# Altium schematic sheet RFShield.SchDoc(RFShield)
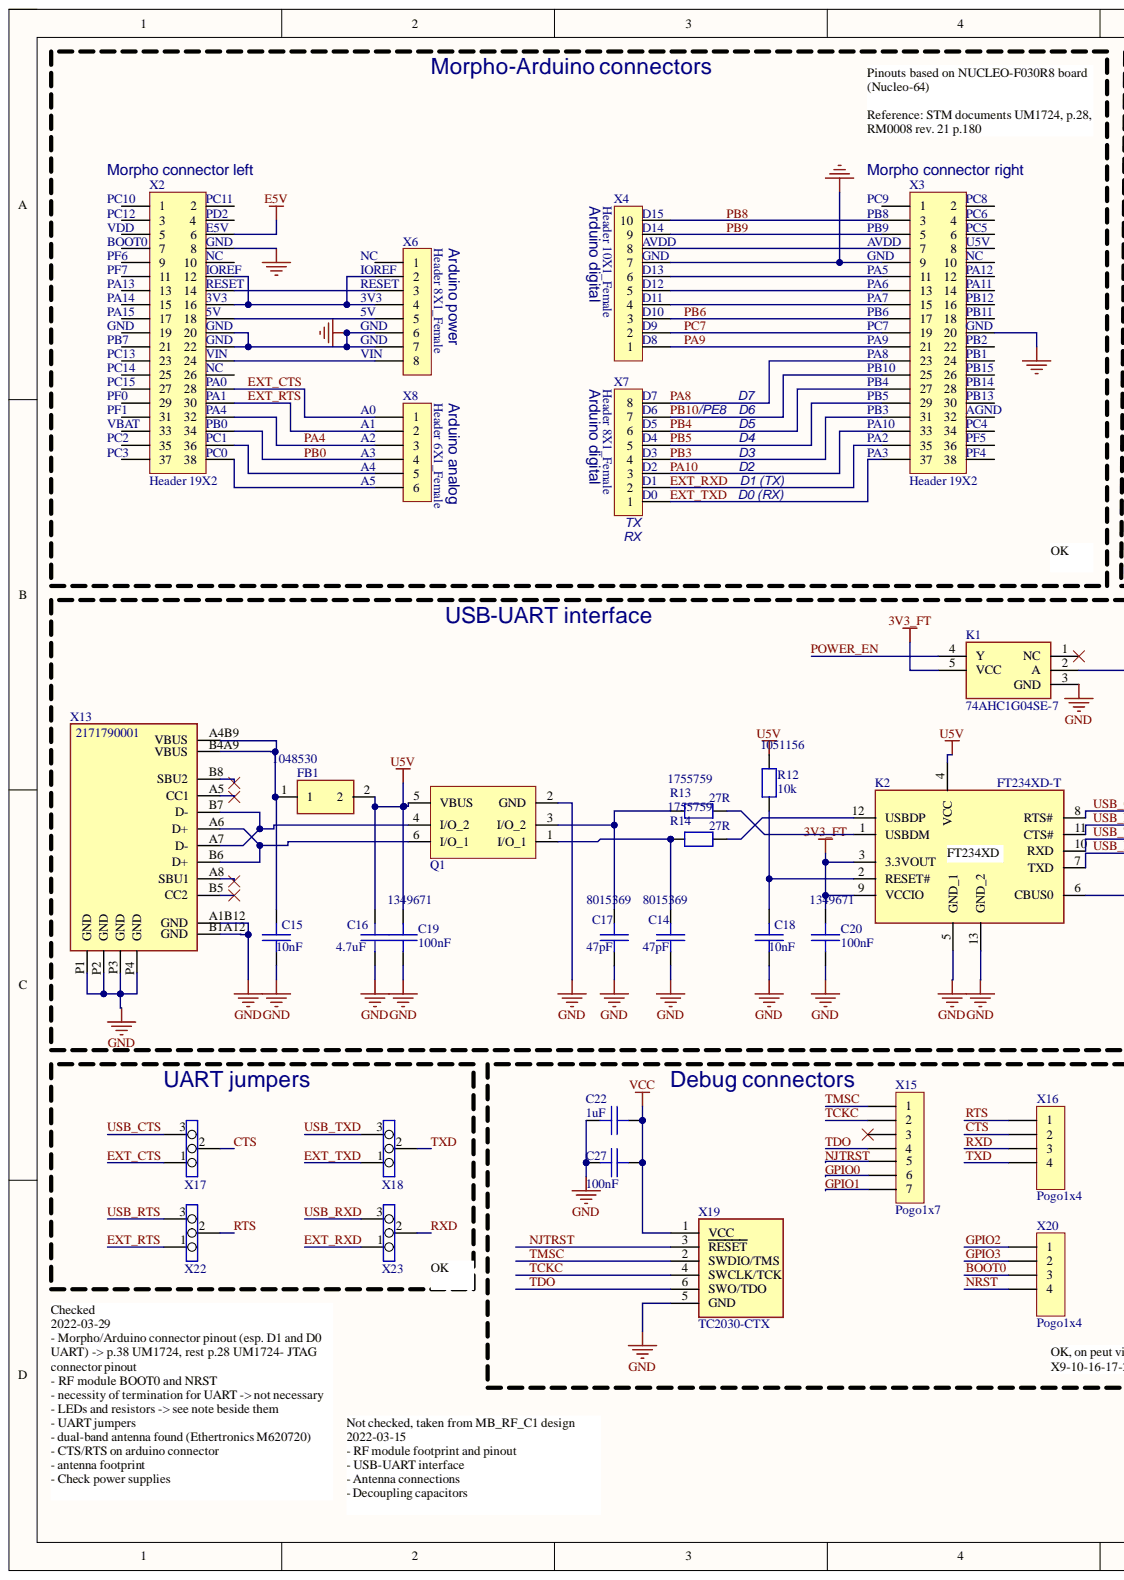
[diagram p0: RFShield.SchDoc, left]
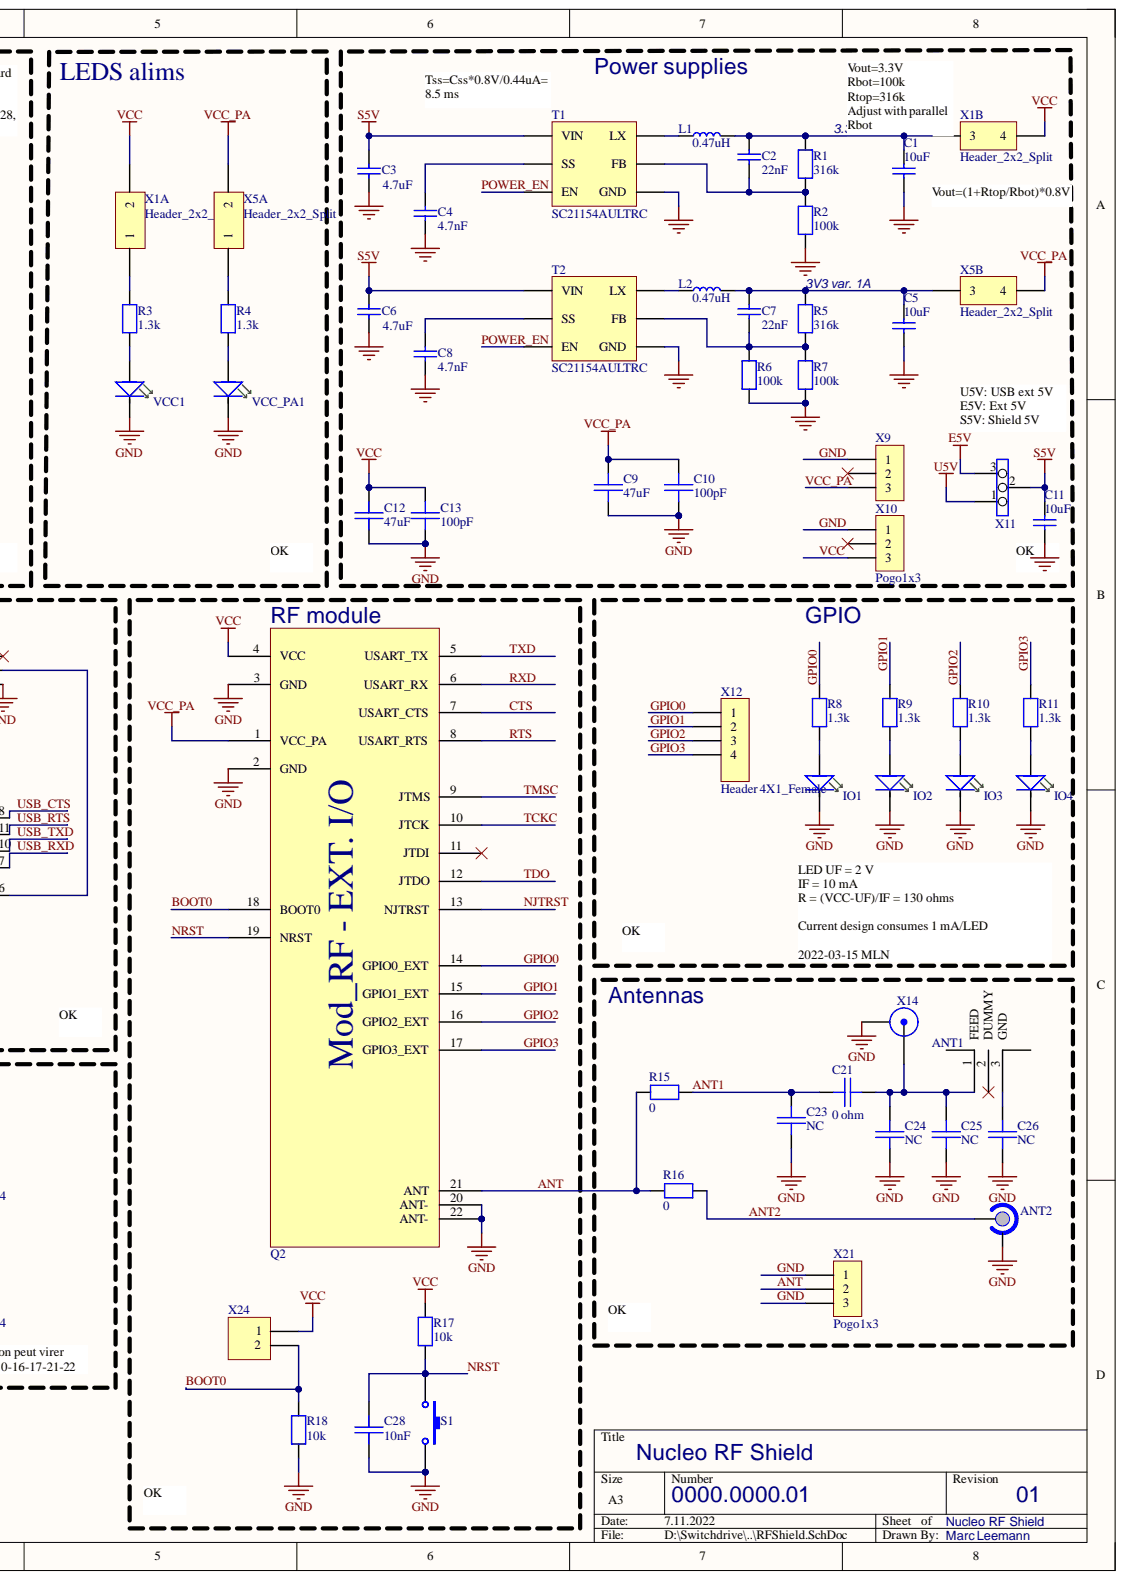
[diagram p1: RFShield.SchDoc, right]

## Parts
ANT1  ANT_M620720  [M620720]  (value not drawn)
ANT2  SMA_ThroughHole_RightAngle  [Amphenol-132225]  (value not drawn)
C1  10uF  [J1-0603]
C2  22nF  [J1-0603]
C3  4.7uF  [J1-0603]
C4  4.7nF  [J1-0603]
C5  10uF  [J1-0603]
C6  4.7uF  [J1-0603]
C7  22nF  [J1-0603]
C8  4.7nF  [J1-0603]
C9  value 47uF  [J1-0603]  (hidden comment: C-47uF-0805)
C10  value 100pF  [J1-0603]  (hidden comment: C-100pF-0603)
C11  10uF  [J1-0603]
C12  value 47uF  [J1-0603]  (hidden comment: C-47uF-0805)
C13  value 100pF  [J1-0603]  (hidden comment: C-100pF-0603)
C14  value 47pF  Supplier Part Number 1: 8015369  [SM/C_0603]  (hidden comment: C-47pF-0402)
C15  value 10nF  [SM/C_0603]  (hidden comment: C-10nF-0603)
C16  value 4.7uF  [SM/C_0805]  (hidden comment: C-4.7uF-0805)
C17  value 47pF  Supplier Part Number 1: 8015369  [SM/C_0603]  (hidden comment: C-47pF-0402)
C18  value 10nF  [SM/C_0603]  (hidden comment: C-10nF-0603)
C19  value 100nF  Supplier Part Number 1: 1349671  [SM/C_0603]  (hidden comment: C-100nF-0402)
C20  value 100nF  Supplier Part Number 1: 1349671  [SM/C_0603]  (hidden comment: C-100nF-0402)
C21  value 0 ohm  [SM/C_0402]  (hidden comment: C-10pF-0402)
C22  value 1uF  [J1-0603]  (hidden comment: C-1uF-0603)
C23  value NC  [SM/C_0402]  (hidden comment: C-10pF-0402)
C24  value NC  [SM/C_0402]  (hidden comment: C-10pF-0402)
C25  value NC  [SM/C_0402]  (hidden comment: C-10pF-0402)
C26  value NC  [SM/C_0402]  (hidden comment: C-10pF-0402)
C27  value 100nF  [J1-0603]  (hidden comment: C-100nF-0603)
C28  value 10nF  [SM/C_0603]  (hidden comment: C-10nF-0603)
FB1  Supplier Part Number 1: 1048530  [SM/C_0603]  (hidden comment: BLM15AX601SN1D)
IO1  LED-GREEN-0603  [SM/D_0603]  (value not drawn)
IO2  LED-GREEN-0603  [SM/D_0603]  (value not drawn)
IO3  LED-GREEN-0603  [SM/D_0603]  (value not drawn)
IO4  LED-GREEN-0603  [SM/D_0603]  (value not drawn)
K1  74AHC1G04SE-7  [SOT65P210X110-5N]
K2  FT234XD-T  [SON45P300X300X80-13N-D]
L1  0.47uH  [6-0805_L]
L2  0.47uH  [6-0805_L]
Q1  USBLC6-2SC6  [SOT95P280X145-6N]  (value not drawn)
Q2  Module_RF_C1  [Module_RF_C1]  (value not drawn)
R1  316k  [J1-0603]
R2  100k  [J1-0603]
R3  value 1.3k  [SM/R_0603]  (hidden comment: R-1.3k-0603)
R4  value 1.3k  [SM/R_0603]  (hidden comment: R-1.3k-0603)
R5  value 316k  [J1-0603]  (hidden comment: 68k)
R6  100k  [J1-0603]
R7  value 100k  [J1-0603]  (hidden comment: 12k)
R8  value 1.3k  [SM/R_0603]  (hidden comment: R-1.3k-0603)
R9  value 1.3k  [SM/R_0603]  (hidden comment: R-1.3k-0603)
R10  value 1.3k  [SM/R_0603]  (hidden comment: R-1.3k-0603)
R11  value 1.3k  [SM/R_0603]  (hidden comment: R-1.3k-0603)
R12  value 10k  Supplier Part Number 1: 1051156  [SM/R_0603]  (hidden comment: R-10k-0402)
R13  value 27R  Supplier Part Number 1: 1755759  [SM/R_0603]  (hidden comment: R-27R-0402)
R14  value 27R  Supplier Part Number 1: 1755759  [SM/R_0603]  (hidden comment: R-27R-0402)
R15  value 0  [SM/R_0402]  (hidden comment: R-0-0402-1%)
R16  value 0  [SM/R_0402]  (hidden comment: R-0-0402-1%)
R17  value 10k  [SM/R_0603]  (hidden comment: R-10k-0603)
R18  value 10k  [J1-0603]  (hidden comment: R-10k-0603)
S1  PTS636 SL43 SMTR LFS  [PTS36]  (value not drawn)
T1  SC21154AULTRC  [SON50P200X60_HS-7N]
T2  SC21154AULTRC  [SON50P200X60_HS-7N]
VCC1  LED-GREEN-0603  [SM/D_0603]  (value not drawn)
VCC_PA1  LED-GREEN-0603  [SM/D_0603]  (value not drawn)
X1  Header_2x2_Split  [2*2P]  (parts A,B)
X2  Header 19X2  [HDR2X19]
X3  Header 19X2  [HDR2X19]
X4  Header 10X1_Female  [HDR1X10]
X5  Header_2x2_Split  [2*2P]  (parts A,B)
X6  Header 8X1_Female  [HDR1X8]
X7  Header 8X1_Female  [HDR1X8]
X8  Header 6X1_Female  [HDR1X6]
X9  Pogo1x3  [Pogo_1x3]  (value not drawn)
X10  Pogo1x3  [Pogo_1x3]
X11  Jumper3  [1*3P_MALE]  (value not drawn)
X12  Header 4X1_Female  [1*4P_FEMALE]
X13  2171790001  [2171790001]
X14  U.FL-R-SMT  [U.FL-R-SMT]  (value not drawn)
X15  Pogo1x7  [Pogo_1x7]
X16  Pogo1x4  [Pogo_1x4]
X17  Jumper3  [1*3P_MALE]  (value not drawn)
X18  Jumper3  [1*3P_MALE]  (value not drawn)
X19  TC2030-CTX  [JTAG_FGN]  (no BOM)
X20  Pogo1x4  [Pogo_1x4]
X21  Pogo1x3  [Pogo_1x3]
X22  Jumper3  [1*3P_MALE]  (value not drawn)
X23  Jumper3  [1*3P_MALE]  (value not drawn)
X24  Header_2_2.54mm  [HDR1X2]  (value not drawn)

## Nets
3V3_FT: C20.2 K1.5(VCC) K2.3(3.3VOUT) K2.9(VCCIO)
E5V: X2.6 X11.3
S5V: C3.2 C6.2 C11.2 T1.1(VIN) T2.1(VIN) X11.2
U5V: C16.2 C19.2 FB1.2 K2.4(VCC) Q1.5(VBUS) R12.2 X11.1
ANT: Q2.21(ANT) R15.1 R16.1 X21.2
ANT1: C21.1 C23.2 R15.2
ANT2: ANT2.1 R16.2
BOOT0: Q2.18(BOOT0) R18.2 X20.3 X24.2
CTS: Q2.7(USART_CTS) X16.2 X17.2
EXT_CTS: X2.28 X8.1 X17.1
EXT_RTS: X2.30 X8.2 X22.1
EXT_RXD: X3.35 X7.2 X23.1
EXT_TXD: X3.37 X7.1 X18.1
GND: ANT2.2 C1.1 C3.1 C4.1 C5.1 C6.1 C8.1 C9.1 C10.1 C11.1 C12.1 C13.1 C14.1 C15.1 C16.1 C17.1 C18.1 C19.1 C20.1 C22.1 C23.1 C24.1 C25.1 C26.1 C27.1 C28.1 IO1.2 IO2.2 IO3.2 IO4.2 K1.3(GND) K2.5(GND_1) K2.13(GND_2) Q1.2(GND) Q2.2(GND) Q2.3(GND) Q2.20(ANT-) Q2.22(ANT-) R2.1 R6.1 R7.1 R18.1 S1.2 T1.5(GND) T2.5(GND) VCC1.2 VCC_PA1.2 X2.8 X2.20 X2.22 X3.9 X3.20 X4.7 X6.6 X6.7 X9.1 X10.1 X13.A1B12(GND) X13.B1A12(GND) X13.P1(GND) X13.P2(GND) X13.P3(GND) X13.P4(GND) X14.2 X19.5(GND) X21.1 X21.3
GPIO0: Q2.14(GPIO0_EXT) R8.2 X12.1 X15.6
GPIO1: Q2.15(GPIO1_EXT) R9.2 X12.2 X15.7
GPIO2: Q2.16(GPIO2_EXT) R10.2 X12.3 X20.1
GPIO3: Q2.17(GPIO3_EXT) R11.2 X12.4 X20.2
NJTRST: Q2.13(NJTRST) X15.5 X19.3(RESET)
NRST: C28.2 Q2.19(NRST) R17.1 S1.1 X20.4
PA4: X2.32 X8.3
PA8: X3.23 X7.8
PA9: X3.21 X4.1
PA10: X3.33 X7.3
PB0: X2.34 X8.4
PB3: X3.31 X7.4
PB4: X3.27 X7.6
PB5: X3.29 X7.5
PB6: X3.17 X4.3
PB8: X3.3 X4.10
PB9: X3.5 X4.9
PB10: X3.25 X7.7
PC7: X3.19 X4.2
POWER_EN: K1.4(Y) T1.3(EN) T2.3(EN)
RTS: Q2.8(USART_RTS) X16.1 X22.2
RXD: Q2.6(USART_RX) X16.3 X23.2
TCKC: Q2.10(JTCK) X15.2 X19.4(SWCLK/TCK)
TDO: Q2.12(JTDO) X15.4 X19.6(SWO/TDO)
TMSC: Q2.9(JTMS) X15.1 X19.2(SWDIO/TMS)
TXD: Q2.5(USART_TX) X16.4 X18.2
USB_CTS: K2.8(RTS#) X17.3
USB_RTS: K2.11(CTS#) X22.3
USB_RXD: K2.7(TXD) X23.3
USB_TXD: K2.10(RXD) X18.3
VCC: C12.2 C13.2 C22.2 C27.2 Q2.4(VCC) R17.2 X1.2 X1.4 X10.3 X19.1(VCC) X24.1
VCC_PA: C9.2 C10.2 Q2.1(VCC_PA) X5.2 X5.4 X9.3
NetANT1_1: ANT1.1 C21.2 C24.2 C25.2 X14.1
NetANT1_3: ANT1.3 C26.2
NetC1_2: C1.2 C2.2 L1.2 R1.2 X1.3
NetC2_1: C2.1 R1.1 R2.2 T1.4(FB)
NetC4_2: C4.2 T1.2(SS)
NetC5_2: C5.2 C7.2 L2.2 R5.2 X5.3
NetC7_1: C7.1 R5.1 R6.2 R7.2 T2.4(FB)
NetC8_2: C8.2 T2.2(SS)
NetC14_2: C14.2 Q1.1(I/O_1) R14.1
NetC15_2: C15.2 FB1.1 X13.A4B9(VBUS) X13.B4A9(VBUS)
NetC17_2: C17.2 Q1.3(I/O_2) R13.1
NetC18_2: C18.2 K2.2(RESET#) R12.1
NetIO1_1: IO1.1 R8.1
NetIO2_1: IO2.1 R9.1
NetIO3_1: IO3.1 R10.1
NetIO4_1: IO4.1 R11.1
NetK1_2: K1.2(A) K2.6(CBUS0)
NetK2_1: K2.1(USBDM) R13.2
NetK2_12: K2.12(USBDP) R14.2
NetL1_1: L1.1 T1.6(LX)
NetL2_1: L2.1 T2.6(LX)
NetQ1_4: Q1.4(I/O_2) X13.A7(D-) X13.B7(D-)
NetQ1_6: Q1.6(I/O_1) X13.A6(D+) X13.B6(D+)
NetR3_1: R3.1 VCC1.1
NetR3_2: R3.2 X1.1
NetR4_1: R4.1 VCC_PA1.1
NetR4_2: R4.2 X5.1
NetX2_12: X2.12 X2.16 X6.2 X6.4
NetX2_14: X2.14 X6.3
NetX2_18: X2.18 X6.5
NetX2_24: X2.24 X6.8
NetX2_36: X2.36 X8.5
NetX2_38: X2.38 X8.6
NetX3_7: X3.7 X4.8
NetX3_11: X3.11 X4.6
NetX3_13: X3.13 X4.5
NetX3_15: X3.15 X4.4

Not connected: ANT1 2; K1 1(NC); Q2 11(JTDI); X2 1 2 3 4 5 7 9 10 11 13 15 17 19 21 23 25 26 27 29 31 33 35 37; X3 1 2 4 6 8 10 12 14 16 18 22 24 26 28 30 32 34 36 38; X6 1; X9 2; X10 2; X13 A5(CC1) A8(SBU1) B5(CC2) B8(SBU2); X15 3

## Library data of the parts
ANT1 = ANT_M620720: Dual-band dipole; Component Kind: Standard; Library Name: ShieldRadio.SCHLIB; Supplier 1: Digi-Key; Supplier Part Number 1: 939-1066-6-ND
ANT2 = SMA_ThroughHole_RightAngle: Amphenol 132225; Component Kind: Standard; Library Name: Module_MB_RF_1312.SCHLIB; Supplier 1: Digi-Key; Supplier 2: Mouser; Supplier Part Number 1: ACX2074-ND; Supplier Part Number 2: 523-132225
C1 C3-C6 C8 C11 = Cap: Capacitor; Value: 10nF; Component Kind: Standard; Library Name: ShieldArduino.SCHLIB; Published: 8-Jun-2000; Revision: July-2002: Re-released for DXP Platform.; Signal Integrity: Cap; Simulation: CAP; Publisher: Altium Limited
C2 C7 = Cap: Capacitor; Component Kind: Standard; Library Name: ShieldArduino.SCHLIB; Published: 8-Jun-2000; Revision: July-2002: Re-released for DXP Platform.; Signal Integrity: Cap; Simulation: CAP; Value: 22nF; Publisher: Altium Limited
C9 C12 = C-47uF-0805: Fabricant: TDK; Fabricant_Ref: C2012X5R0J476M125AC; Technologie: X5R; Tolerance: 20%; Voltage: 6.3V; Component Kind: Standard; Simulation: CAP; Supplier 1: Digi-Key; Supplier 1b: DigiReel; Supplier 2: Mouser; Supplier Part Number 1: 587-4280-1-ND; Supplier Part Number 1b: 445-5987-6-ND; Supplier Part Number 2: 810-C2012X5R0J476M; Library Name: cc1312_RM1_EVK.SCHLIB
C10 C13 = C-100pF-0603: Fabricant: Murata; Fabricant_Ref: GRM1885C2A101JA01D; Technologie: NPO; Tolerance: 5%; Voltage: 100V; Component Kind: Standard; Simulation: CAP; Supplier 1: Digi-Key; Supplier 1b: DigiReel; Supplier 2: Mouser; Supplier Part Number 1: 1292-1474-1-ND; Supplier Part Number 1b: 490-1351-6-ND; Supplier Part Number 2: 81-GRM1885C2A101JA01; Library Name: cc1312_RM1_EVK.SCHLIB
C14 C17 = C-47pF-0402: Fabricant: Samsung; Fabricant_Ref: CL05C470JB51PNC; Technologie: NP0; Tolerance: 5%; Voltage: 50V; Component Kind: Standard; Library Name: Module_MB_RF_1312.SCHLIB; Supplier 1: RSComponents
C15 C18 C28 = C-10nF-0603: Fabricant: Murata; Fabricant_Ref: GRM188R71H103KA01D; Technologie: X7R; Tolerance: 10%; Voltage: 50V; Component Kind: Standard; Library Name: Module_MB_RF_1312.SCHLIB; Supplier 1: Digi-Key; Supplier 1b: DigiReel; Supplier 2: Mouser; Supplier Part Number 1: 490-1512-1-ND; Supplier Part Number 1b: 490-1512-6-ND; Supplier Part Number 2: 81-GRM39X103K50D
  C28: Simulation: CAP
C16 = C-4.7uF-0805: Fabricant: Murata; Fabricant_Ref: GRM188R71E104JA01D; Technologie: 5%; Voltage: 25V; Component Kind: Standard; Library Name: Module_MB_RF_1312.SCHLIB; Supplier 1: Digi-Key; Supplier Part Number 1: 445-14527-1-ND
C19 C20 = C-100nF-0402: Fabricant: Samsung; Fabricant_Ref: CL05B104KA5NNNC; Technologie: X7R; Tolerance: 10%; Voltage: 25V; Component Kind: Standard; Library Name: Module_MB_RF_1312.SCHLIB; Supplier 1: RSComponents; Supplier 1b: DigiReel; Supplier Part Number 1b: 1276-6720-6-ND
C21 C23-C26 = C-10pF-0402: Fabricant: Murata; Fabricant_Ref: GJM1555C1H8R2CB01D; Technologie: NP0; Tolerance: 0.25pF; Voltage: 50V; Component Kind: Standard; Library Name: Module_MB_RF_1312.SCHLIB; Simulation: CAP; Supplier 1: Digi-Key; Supplier 2: Mouser; Supplier Part Number 1: 712-1253-1-ND; Supplier Part Number 2: 609-500R07S100JV4T
C22 = C-1uF-0603: Fabricant: Murata; Fabricant_Ref: C1608X7R1C105K080AC; Technologie: X7R; Tolerance: 10%; Voltage: 16V; Component Kind: Standard; Simulation: CAP; Supplier 1: Digi-Key; Supplier 1b: DigiReel; Supplier 2: Mouser; Supplier Part Number 1: 445-1604-1-ND; Supplier Part Number 1b: 445-1604-6-ND; Supplier Part Number 2: 810-C1608X7R1C105K; Library Name: cc1312_RM1_EVK.SCHLIB
C27 = C-100nF-0603: Fabricant: TDK; Fabricant_Ref: C1608X7R1E104K080AA; Technologie: X7R; Tolerance: 10%; Voltage: 25V; Component Kind: Standard; Simulation: CAP; Supplier 1: Digi-Key; Supplier 1b: DigiReel; Supplier 2: Mouser; Supplier Part Number 1: 445-1316-1-ND; Supplier Part Number 1b: 445-1316-6-ND; Supplier Part Number 2: 810-C1608X7R1E104K; Library Name: cc1312_RM1_EVK.SCHLIB
FB1 = BLM15AX601SN1D: Ferrite Bead; Manufacturer_Name: Murata Electronics; Fabricant: Murata; Arrow Part Number: BLM15AX601SN1D; Arrow Price/Stock: https://www.arrow.com/en/products/blm15ax601sn1d/murata-manufacturing; Component Kind: Standard; Datasheet Link: https://www.murata.com/en-us/products/productdetail?partno=BLM15AX601SN1%23; Fabricant_Ref: BLM15AX601SN1D; Height: 0.55mm; Library Name: Module_MB_RF_1312.SCHLIB; Manufacturer_Part_Number: BLM15AX601SN1D; Mouser Part Number: 81-BLM15AX601SN1D; Mouser Price/Stock: https://www.mouser.co.uk/ProductDetail/Murata-Electronics/BLM15AX601SN1D?qs=MY6wChARw2zrjX4xpWbUQA%3D%3D; Supplier 1: RSComponents; Supplier 1b: DigiReel; Supplier Part Number 1b: 490-5441-6-ND
K1 = 74AHC1G04SE-7: Integrated Circuit; Manufacturer_Name: Diodes Inc.; Fabricant: Diodes Inc; Arrow Part Number: 74AHC1G04SE-7; Arrow Price/Stock: https://www.arrow.com/en/products/74ahc1g04se-7/diodes-incorporated; Component Kind: Standard; Datasheet Link: https://componentsearchengine.com/Datasheets/2/74AHC1G04SE-7.pdf; Fabricant_Ref: 74AHC1G04SE-7; Height: 1.1mm; Library Name: Module_MB_RF_1312.SCHLIB; Manufacturer_Part_Number: 74AHC1G04SE-7; Mouser Part Number: 621-74AHC1G04SE-7; Mouser Price/Stock: https://www.mouser.co.uk/ProductDetail/Diodes-Incorporated/74AHC1G04SE-7?qs=aOs975IaWlnsld15OHCVBA%3D%3D; Supplier 1: Digikey; Supplier 1b: DigiReel; Supplier 2: Mouser; Supplier Part Number 1: 74AHC1G04SE-7DICT-ND; Supplier Part Number 1b: 74AHC1G04SE-7DIDKR-ND
K2 = FT234XD-T: Integrated Circuit; Manufacturer_Name: FTDI(Future Designs); Fabricant: FTDI; Component Kind: Standard; Datasheet Link: https://datasheet.lcsc.com/szlcsc/1811190128_Future-Designs-FT234XD-T_C89606.pdf; Fabricant_Ref: FT234XD-T; Height: 0.8mm; Library Name: Module_MB_RF_1312.SCHLIB; Manufacturer_Part_Number: FT234XD-T; Supplier 1: Digikey; Supplier 2: Mouser; Supplier Part Number 1: 768-1345-ND
L1 L2 = Bobine: Coil; Component Kind: Standard; Library Name: ShieldArduino.SCHLIB
Q1 = TVS-USBLC6-2SC6: 17V Clamp 5A (8/20µs) Ipp Tvs Diode Surface Mount SOT-23-6; Component Kind: Standard; Library Name: Module_MB_RF_C1.SCHLIB; Supplier 1: Digi-Key; Supplier 2: Mouser; Supplier Part Number 1: 497-4492-1-ND; Supplier Part Number 2: 511-USBLC6-2SC6
Q2 = Module_RF_C1: Pour la conception du module RF, footprint C1; Component Kind: Standard; Library Name: Module_MB_RF_C1.SCHLIB
R1 R2 R5-R7 R17 R18 = R-10k-0603: Fabricant: Panasonic; Fabricant_Ref: ERJ-3EKF1002V; Puissance: 100 mW; Tension: 75V; Tolerance: 1%; Component Kind: Standard; Signal Integrity: Res; Supplier 1: Digi-Key; Supplier 1b: DigiReel; Supplier 2: Mouser; Supplier Part Number 1: P10.0KHCT-ND; Supplier Part Number 1b: P10.0KHDKR-ND; Supplier Part Number 2: 667-ERJ-3EKF1002V
  R1: Value: 316k
  R2 R6: Value: 100k
  R17: Library Name: Module_MB_RF_1312.SCHLIB
  R1 R2 R5-R7 R18: Library Name: cc1312_RM1_EVK.SCHLIB
R3 R4 R8-R11 = R-1.3k-0603: Fabricant: Panasonic; Fabricant_Ref: ERJ-3EKF1501V; Puissance: 100 mW; Tension: 75V; Tolerance: 1%; Component Kind: Standard; Library Name: Module_MB_RF_1312.SCHLIB; Signal Integrity: Res; Supplier 1: Digi-Key; Supplier 2: Mouser; Supplier Part Number 1: P1.30KHCT-ND; Supplier Part Number 2: 667-ERJ-3EKF1301V
R12 = R-10k-0402: Fabricant: Samsung; Fabricant_Ref: RC1005F103CS; Puissance: 63 mW; Tension: 75V; Tolerance: 1%; Component Kind: Standard; Library Name: Module_MB_RF_1312.SCHLIB; Supplier 1: RSComponents; Supplier 1b: DigiReel; Supplier Part Number 1b: 1276-3431-6-ND
R13 R14 = R-27R-0402: Fabricant: TE Connectivity; Fabricant_Ref: CRGCQ0402F27R; Puissance: 63 mW; Tension: 75V; Tolerance: 1%; Component Kind: Standard; Library Name: Module_MB_RF_1312.SCHLIB; Supplier 1: RSComponents; Supplier 1b: DigiReel
R15 R16 = R-0-0402-1%: Fabricant: Yageo; Fabricant_Ref: AC0402JR-070RL; Puissance: 63 mW; Tension: 50V; Tolerance: 1%; Component Kind: Standard; Library Name: Module_MB_RF_C1.SCHLIB; Signal Integrity: Res; Supplier 1: Digi-Key; Supplier 1b: DigiReel; Supplier 2: Mouser; Supplier Part Number 1: 311-0.0LBCT-ND
S1 = SW-PTS36: Switch; Fabricant: C&K; Component Kind: Standard; Fabricant_Ref: PTS636 SL43 SMTR LFS; LatestRevisionNote: Re-released for DXP Platform.; Library Name: Module_MB_RF_1312.SCHLIB; PackageReference: SPST-2; Supplier 1: Digi-Key; Supplier 2: Mouser; Supplier Part Number 1: CKN12309-1-ND; Publisher: Altium Limited
T1 T2 = SC21154: Switching voltage regulator, adjustable; Component Kind: Standard; Library Name: ShieldRadio.SCHLIB; Supplier 1: Mouser; Supplier Part Number 1: 947-SC21154AULTRC
X1 X5 = Header_2x2_Split: Header_2x2 divided in 2 symbol parts; Component Kind: Standard; Library Name: ShieldRadio.SCHLIB; Supplier 1: Digikey; Supplier 2: Mouser
X2 X3 = Header 19X2: Header, 19-Pin, Dual row; Component Kind: Standard; Library Name: Nucleo_64_1.SCHLIB; Revision: 17-Jul-2002: Re-released for DXP Platform.; Signal Integrity: Connector; Publisher: Altium Limited
X4 = Header 10X1_Female: Header, 10-Pin, Single row,female; Component Kind: Standard; LatestRevisionDate: 17-Jul-2002; LatestRevisionNote: Re-released for DXP Platform.; Library Name: Nucleo_64.SCHLIB; Signal Integrity: Connector; Publisher: Altium Limited
X6 X7 = Header 8X1_Female: Header, 8-Pin, Single row,female; Component Kind: Standard; LatestRevisionDate: 17-Jul-2002; LatestRevisionNote: Re-released for DXP Platform.; Library Name: Nucleo_64.SCHLIB; Signal Integrity: Connector; Publisher: Altium Limited
X8 = Header 6X1_Female: Header, 6-Pin, Single row,female; Component Kind: Standard; LatestRevisionDate: 17-Jul-2002; LatestRevisionNote: Re-released for DXP Platform.; Library Name: Nucleo_64.SCHLIB; Signal Integrity: Connector; Publisher: Altium Limited
X9 X10 X21 = Pogo1x3: Position Spring Piston Connector Through Hole; Component Kind: Standard; LatestRevisionDate: 17-Jul-2002; LatestRevisionNote: Re-released for DXP Platform.; Supplier 1: Digikey; Supplier Part Number 1: ED8197-03-ND; Publisher: Altium Limited
  X9 X10: Library Name: Module_MB_RF_5.SCHLIB
  X21: Library Name: Module_MB_RF_1312.SCHLIB; Supplier 2: Mouser
X12 = Header 4X1_Female: Header, 6-Pin, Single row,female; Component Kind: Standard; LatestRevisionDate: 17-Jul-2002; LatestRevisionNote: Re-released for DXP Platform.; Library Name: Module_MB_RF_C1.SCHLIB; Publisher: Altium Limited
X13 = 2171790001: USB-C Connector; Fabricant: Molex; Component Kind: Standard; Datasheet Link: https://www.molex.com/webdocs/datasheets/pdf/en-us/2171750001_IO_CONNECTORS.pdf; Fabricant_Ref: 2171790001; Height: 5.26mm; Library Name: Module_MB_RF_1312.SCHLIB; Manufacturer_Name: Molex; Manufacturer_Part_Number: 2171790001; Supplier 1: Digikey; Supplier 1b: DigiReel; Supplier 2: Mouser; Supplier Part Number 1: 900-2171790001CT-ND; Supplier Part Number 1b: 900-2171790001DKR-ND
X15 = Pogo1x7: Position Spring Piston Connector Through Hole; Component Kind: Standard; LatestRevisionDate: 17-Jul-2002; LatestRevisionNote: Re-released for DXP Platform.; Library Name: Module_MB_RF_5.SCHLIB; Supplier 1: Digikey; Supplier Part Number 1: ED8197-07-ND; Publisher: Altium Limited
X16 X20 = Pogo1x4: Position Spring Piston Connector Through Hole; Component Kind: Standard; LatestRevisionDate: 17-Jul-2002; LatestRevisionNote: Re-released for DXP Platform.; Library Name: Module_MB_RF_5.SCHLIB; Supplier 1: Digikey; Supplier Part Number 1: ED8197-04-ND; Publisher: Altium Limited
X19 = Fixation pour cable TC2030-CTX: Câble in situ Tag-Connect; Manufacturer: Tag-Connect LLC; Manufacturer P/N: TC2030-MCP-10; Component: Fixation connecteur JTAG; ID: 2132; Value: M2.5; Component Type Category Connector Type: Fixation connecteur JTAG; ComponentLink1Description: Datasheet; ComponentLink1URL: https://www.tag-connect.com/wp-content/uploads/bsk-pdf-manager/TC2030-MCP_Datasheet_4.pdf; ComponentLink2Description: Supplier; ComponentLink2URL: https://www.digikey.fr/products/fr?keywords=TC2030-MCP-10-ND; RoHS Compliant: True; Supplier 2: Farnell; Supplier Part Number 2: 2396152; Library Name: cc1312_RM1_EVK.SCHLIB
IO1-IO4 VCC1 VCC_PA1 = LED-GREEN-0603: Component Kind: Standard; Library Name: Module_MB_RF_1312.SCHLIB; Supplier 1: Digi-Key; Supplier 2: Mouser; Supplier Part Number 1: P11480DKR-ND
X11 X17 X18 X22 X23 = Jumper3: Component Kind: Standard; Library Name: ShieldRadio.SCHLIB
X14 = U.FL-R-SMT: Component Kind: Standard; Library Name: Module_MB_RF_1312.SCHLIB; Supplier 1: Digi-Key; Supplier 2: Mouser; Supplier Part Number 1: H9161CT-ND; Supplier Part Number 2: 798-U.FL-R-SMT10
X24 = Header_2_2.54mm: Component Kind: Standard; LatestRevisionDate: 17-Jul-2002; LatestRevisionNote: Re-released for DXP Platform.; Supplier 1: Digi-Key; Supplier Part Number 1: S2011E-01-ND; Library Name: cc1312_RM1_EVK.SCHLIB; Publisher: Altium Limited
